# Altium schematic sheet BiSheFinalA.SchDoc(BiSheFinalA)
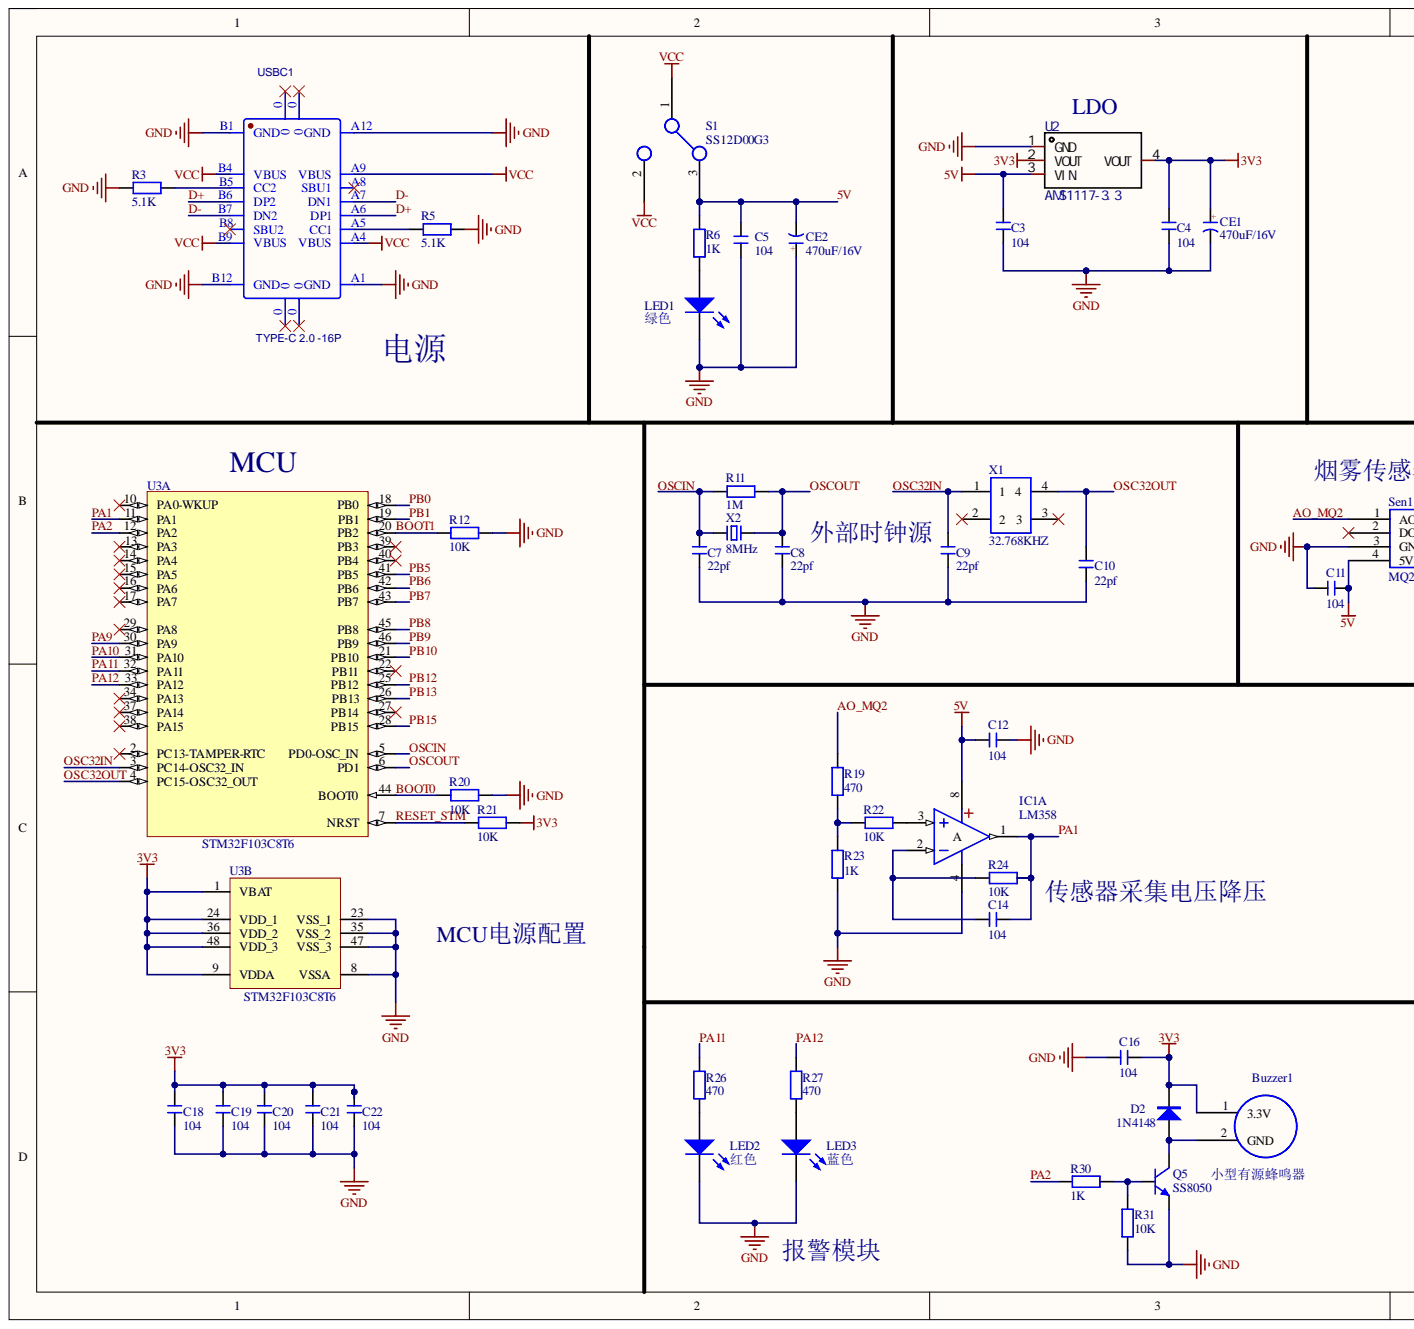
[diagram p0: BiSheFinalA.SchDoc, left]
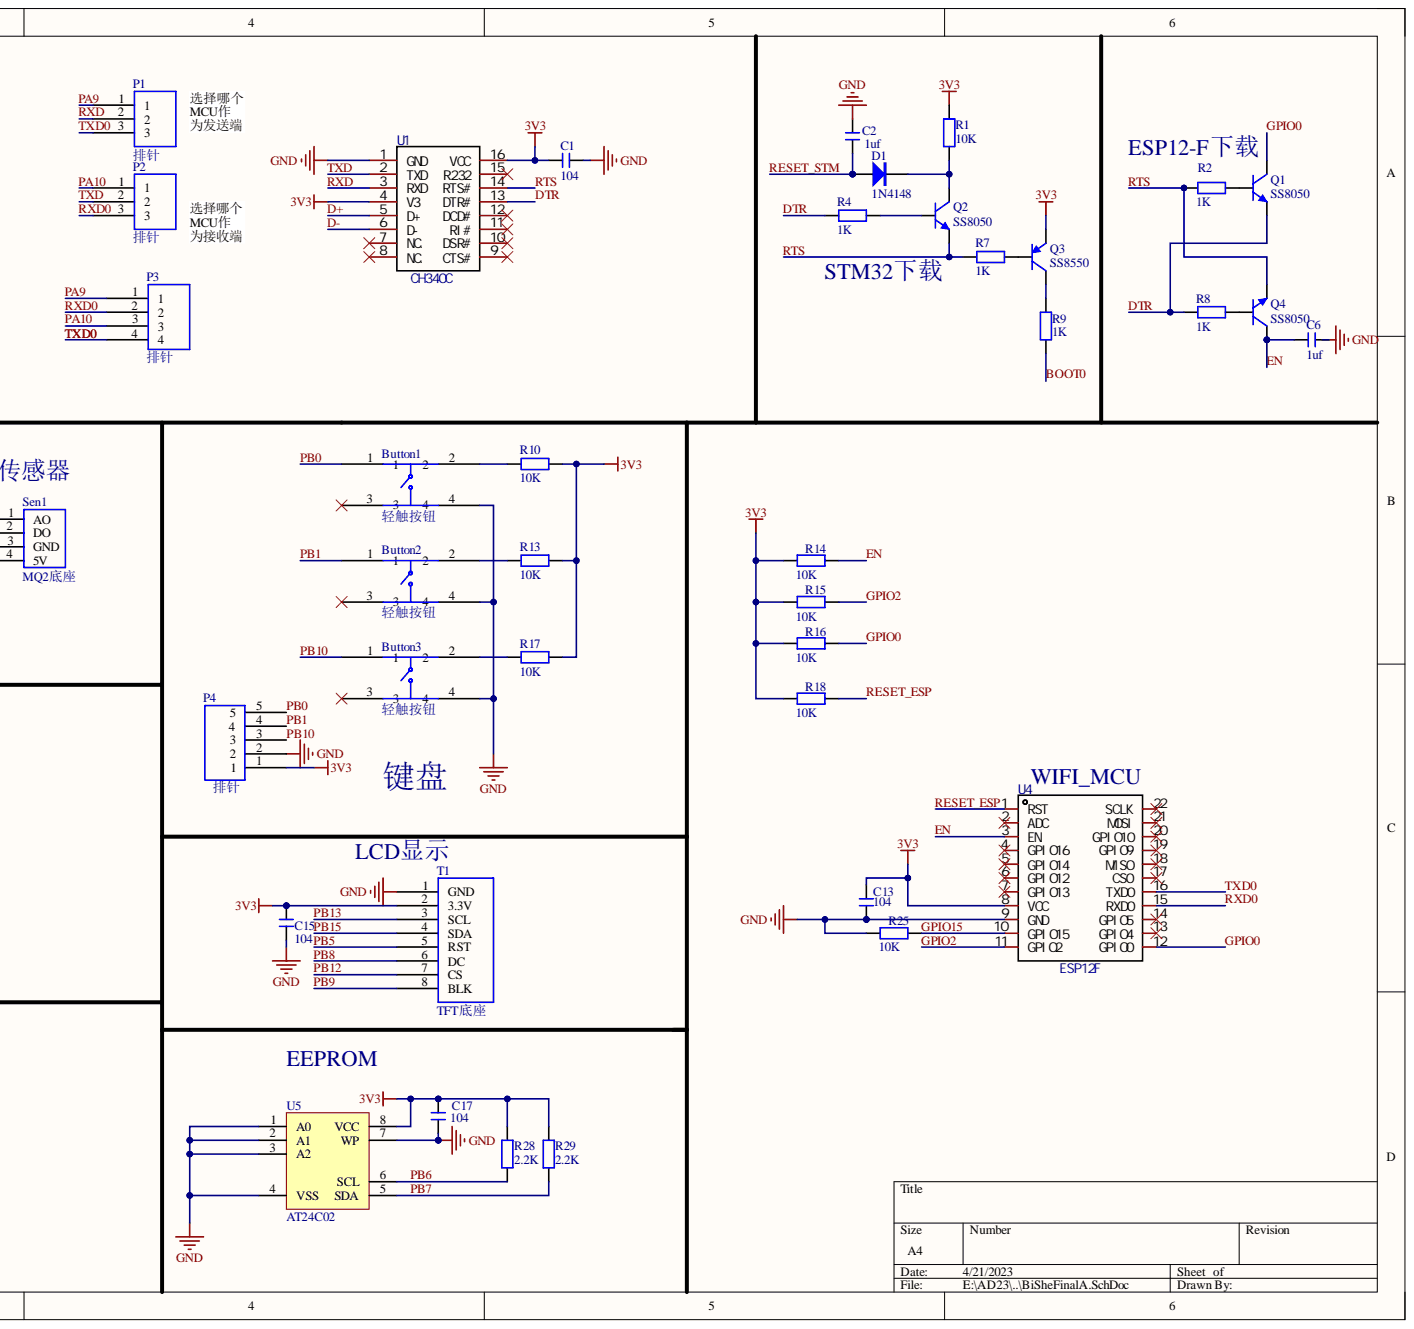
[diagram p1: BiSheFinalA.SchDoc, right]

## Parts
Button1  轻触按钮  [Button]
Button2  轻触按钮  [Button]
Button3  轻触按钮  [Button]
Buzzer1  小型有源蜂鸣器  [Buzzer_Mini]
C1  104  [C 0805_L]
C2  1uf  [C 0805_L]
C3  104  [C 0805_L]
C4  104  [C 0805_L]
C5  104  [C 0805_L]
C6  1uf  [C 0805_L]
C7  22pf  [C 0805_L]
C8  22pf  [C 0805_L]
C9  22pf  [C 0805_L]
C10  22pf  [C 0805_L]
C11  104  [C 0805_L]
C12  104  [C 0805_L]
C13  104  [C 0805_L]
C14  104  [C 0805_L]
C15  104  [C 0805_L]
C16  104  [C 0805_L]
C17  104  [C 0805_L]
C18  104  [C 0805_L]
C19  104  [C 0805_L]
C20  104  [C 0805_L]
C21  104  [C 0805_L]
C22  104  [C 0805_L]
CE1  470uF/16V  [CAP 3.5*8*11.5]
CE2  470uF/16V  [CAP 3.5*8*11.5]
D1  1N4148  [SOD-123]
D2  1N4148  [SOD-123]
IC1  LM358  [SOP8_N]  (part A)
LED1  绿色  [LED 5MM-B]
LED2  红色  [LED 5MM-B]
LED3  蓝色  [LED 5MM-B]
P1  排针  [3Pin]
P2  排针  [3Pin]
P3  排针  [4PIN]
P4  排针  [5pin]
Q1  SS8050  [SOT23-3N]
Q2  SS8050  [SOT23-3N]
Q3  SS8550  [SOT23-3N]
Q4  SS8050  [SOT23-3N]
Q5  SS8050  [SOT23-3N]
R1  10K  [R 0805_L]
R2  1K  [R 0805_L]
R3  5.1K  [R 0805_L]
R4  1K  [R 0805_L]
R5  5.1K  [R 0805_L]
R6  1K  [R 0805_L]
R7  1K  [R 0805_L]
R8  1K  [R 0805_L]
R9  1K  [R 0805_L]
R10  10K  [R 0805_L]
R11  1M  [R 0805_L]
R12  10K  [R 0805_L]
R13  10K  [R 0805_L]
R14  10K  [R 0805_L]
R15  10K  [R 0805_L]
R16  10K  [R 0805_L]
R17  10K  [R 0805_L]
R18  10K  [R 0805_L]
R19  470  [R 0805_L]
R20  10K  [R 0805_L]
R21  10K  [R 0805_L]
R22  10K  [R 0805_L]
R23  1K  [R 0805_L]
R24  10K  [R 0805_L]
R25  10K  [R 0805_L]
R26  470  [R 0805_L]
R27  470  [R 0805_L]
R28  2.2K  [R 0805_L]
R29  2.2K  [R 0805_L]
R30  1K  [R 0805_L]
R31  10K  [R 0805_L]
S1  SS12D00G3  [SS12D00G3]
Sen1  MQ2底座  [MQ2底座]
T1  TFT底座  [TFT底座]
U1  CH340C  [SOP-16_L10.0-W3.9-P1.27-LS6.0-BL]
U2  AMS1117-3.3  [SOT-223-3_L6.5-W3.4-P2.30-LS7.0-BR]
U3  STM32F103C8T6  [LQFP48_N]  (parts A,B)
U4  ESP12F  [WIFIM-SMD_ESP-12F-ESP8266MOD]
U5  AT24C02  [SOIC8_N]
USBC1  TYPE-C 2.0 -16P  [USB-C-SMD_TYPE-C-USB-5]
X1  32.768KHZ  [MC-306]
X2  8MHz  [OSC HC-49S]

## Nets
3V3: Buzzer1.1 C1.1 C4.1 C13.1 C15.2 C16.2 C17.2 C18.1 C19.1 C20.1 C21.1 C22.1 CE1.1 D2.2 P4.1 Q3.2 R1.1 R10.1 R13.1 R14.1 R15.1 R16.1 R17.1 R18.1 R21.1 R28.1 R29.1 T1.2(3.3V) U1.4(V3) U1.16(VCC) U2.2(VOUT) U2.4(VOUT) U3.1(VBAT) U3.9(VDDA) U3.24(VDD_1) U3.36(VDD_2) U3.48(VDD_3) U4.8(VCC) U5.8(VCC)
GND: Button1.4 Button2.4 Button3.4 C1.2 C2.1 C3.2 C4.2 C5.1 C6.2 C7.2 C8.2 C9.2 C10.2 C11.1 C12.1 C13.2 C15.1 C16.1 C17.1 C18.2 C19.2 C20.2 C21.2 C22.2 CE1.2 CE2.1 IC1.4 LED1.2 LED2.2 LED3.2 P4.2 Q5.2 R3.2 R5.1 R12.1 R20.1 R23.2 R25.1 R31.2 Sen1.3(GND) T1.1(GND) U1.1(GND) U2.1(GND) U3.8(VSSA) U3.23(VSS_1) U3.35(VSS_2) U3.47(VSS_3) U4.9(GND) U5.1(A0) U5.2(A1) U5.3(A2) U5.4(VSS) U5.7(WP) USBC1.A1(GND) USBC1.A12(GND) USBC1.B1(GND) USBC1.B12(GND)
VCC: S1.1 S1.2 USBC1.A4(VBUS) USBC1.A9(VBUS) USBC1.B4(VBUS) USBC1.B9(VBUS)
5V: C3.1 C5.2 C11.2 C12.2 CE2.2 IC1.8(A) R6.1 S1.3 Sen1.4(5V) U2.3(VIN)
AO_MQ2: R19.1 Sen1.1(AO)
BOOT0: R9.2 R20.2 U3.44(BOOT0)
BOOT1: R12.2 U3.20(PB2)
D+: U1.5(D+) USBC1.A6(DP1) USBC1.B6(DP2)
D-: U1.6(D-) USBC1.A7(DN1) USBC1.B7(DN2)
DTR: Q1.2 R4.1 R8.2 U1.13(DTR#)
EN: C6.1 Q4.3 R14.2 U4.3(EN)
GPIO0: Q1.3 R16.2 U4.12(GPIO0)
GPIO2: R15.2 U4.11(GPIO2)
GPIO15: R25.2 U4.10(GPIO15)
OSC32IN: C9.1 U3.3(PC14-OSC32_IN) X1.1
OSC32OUT: C10.1 U3.4(PC15-OSC32_OUT) X1.4
OSCIN: C7.1 R11.2 U3.5(PD0-OSC_IN) X2.1
OSCOUT: C8.1 R11.1 U3.6(PD1) X2.2
PA1: C14.1 IC1.1 R24.2 U3.11(PA1)
PA2: R30.1 U3.12(PA2)
PA9: P1.1 P3.1 U3.30(PA9)
PA10: P2.1 P3.3 U3.31(PA10)
PA11: R26.1 U3.32(PA11)
PA12: R27.1 U3.33(PA12)
PB0: Button1.1 P4.5 U3.18(PB0)
PB1: Button2.1 P4.4 U3.19(PB1)
PB5: T1.5(RST) U3.41(PB5)
PB6: R28.2 U3.42(PB6) U5.6(SCL)
PB7: R29.2 U3.43(PB7) U5.5(SDA)
PB8: T1.6(DC) U3.45(PB8)
PB9: T1.8(BLK) U3.46(PB9)
PB10: Button3.1 P4.3 U3.21(PB10)
PB12: T1.7(CS) U3.25(PB12)
PB13: T1.3(SCL) U3.26(PB13)
PB15: T1.4(SDA) U3.28(PB15)
RESET_ESP: R18.2 U4.1(RST)
RESET_STM: C2.2 D1.1 R21.2 U3.7(NRST)
RTS: Q2.2 Q4.2 R2.2 R7.1 U1.14(RTS#)
RXD: P1.2 U1.3(RXD)
RXD0: P2.3 P3.2 U4.15(RXD0)
TXD: P2.2 U1.2(TXD)
TXD0: P1.3 P3.4 U4.16(TXD0)
NetButton1_2: Button1.2 R10.2
NetButton2_2: Button2.2 R13.2
NetButton3_2: Button3.2 R17.2
NetBuzzer1_2: Buzzer1.2 D2.1 Q5.3
NetC14_2: C14.2 IC1.2 R24.1
NetD1_2: D1.2 Q2.3 R1.2
NetIC1_3: IC1.3 R22.1
NetLED1_1: LED1.1 R6.2
NetLED2_1: LED2.1 R26.2
NetLED3_1: LED3.1 R27.2
NetQ1_1: Q1.1 R2.1
NetQ2_1: Q2.1 R4.2
NetQ3_1: Q3.1 R7.2
NetQ3_3: Q3.3 R9.1
NetQ4_1: Q4.1 R8.1
NetQ5_1: Q5.1 R30.2 R31.1
NetR3_1: R3.1 USBC1.B5(CC2)
NetR5_2: R5.2 USBC1.A5(CC1)
NetR19_2: R19.2 R22.2 R23.1

Not connected: Button1 3; Button2 3; Button3 3; Sen1 2(DO); U1 7(NC.) 8(NC.) 9(CTS#) 10(DSR#) 11(RI#) 12(DCD#) 15(R232); U3 2(PC13-TAMPER-RTC) 10(PA0-WKUP) 13(PA3) 14(PA4) 15(PA5) 16(PA6) 17(PA7) 22(PB11) 27(PB14) 29(PA8) 34(PA13) 37(PA14) 38(PA15) 39(PB3) 40(PB4); U4 2(ADC) 4(GPIO16) 5(GPIO14) 6(GPIO12) 7(GPIO13) 13(GPIO4) 14(GPIO5) 17(CS0) 18(MISO) 19(GPIO9) 20(GPIO10) 21(MOSI) 22(SCLK); USBC1 0 A8(SBU1) B8(SBU2); X1 2 3

## Library data of the parts
Button1-Button3 = Button: 6*6*7轻触按钮; Component Kind: Standard; Library Name: BiShe.SchLib
Buzzer1 = Buzzer: 蜂鸣器; Component Kind: Standard; Library Name: BiSheFinal.SCHLIB
C1-C22 = C: 贴片电容; BOM名称: 无极性贴片电容; 淘宝ID: 封装库来自淘宝 ID：黄晶宝石; Component Kind: Standard; Library Name: 0.1 - 电阻-电容-电感.SchLib; 唯一出售店铺网址: https://shop463601444.taobao.com; 联系售后，技术支持: https://shop463601444.taobao.com
CE1 CE2 = CE: 直插电解电容; 淘宝ID: 封装库来自淘宝 ID：黄晶宝石; BOM名称: 直插电解电容; Component Kind: Standard; Library Name: 0.1 - 电阻-电容-电感.SchLib; 联系售后: https://item.taobao.com/item.htm?id=566775463719
D1 D2 = 1N4148: 开关二极管; BOM名称: 高速开关二极管; 淘宝ID: 封装库来自淘宝 ID：黄晶宝石; Component Kind: Standard; Library Name: 0.2 - 二极管-整流桥.SchLib
IC1 = LM358: 双路运放; 学习资源: 最新版本发布https://item.taobao.com/item.htm?id=566775463719; BOM名称: 双路运放; Component Kind: Standard; Library Name: 1.0 - 常见芯片.SchLib
LED1-LED3 = LED-5MM: 直插5mm LED; BOM名称: 5mm插件LED; 版权归属: 封装库来自淘宝 ID：黄晶宝石; Component Kind: Standard; Library Name: 0.3 - LED发光二极管.SchLib; 学习资源: https://item.taobao.com/item.htm?id=566775463719
P1 P2 = 3Pin: 3p排针; Component Kind: Standard; Library Name: BiSheFinal.SCHLIB
P3 = 4Pin: 4p排针; Component Kind: Standard; Library Name: BiSheFinal.SCHLIB
P4 = 5Pin: 5Pin排针; Component Kind: Standard; Library Name: BiSheFinal.SCHLIB
Q1 Q2 Q4 Q5 = 8050-SMD: 高频放大-NPN型; 属性: 40V 1.5A 1W 100MHZ; 版权归属: 封装库来自淘宝 ID：黄晶宝石; 联系售后: 最新版本发布https://item.taobao.com/item.htm?id=566775463719; BOM名称: 高频放大-NPN型; Component Kind: Standard; Library Name: 0.4 - 三极管.SchLib
Q3 = 8550-SMD: 高频放大-PNP型; 学习资源: 最新版本发布https://item.taobao.com/item.htm?id=566775463719; 属性: 40V 1.5A 1W 100MHZ; 版权归属: 封装库来自淘宝 ID：黄晶宝石; BOM名称: 高频放大-PNP型; Component Kind: Standard; Library Name: 0.4 - 三极管.SchLib
R1-R31 = R: 贴片电阻; 封装库来自淘宝卖家 ID：黄晶宝石: 其他店铺购入均为盗版，不享受售后技术支持服务，请立即申请退款; 版权归属: 来自淘宝 ID：黄晶宝石; BOM名称: 贴片电阻; Component Kind: Standard; Library Name: 0.1 - 电阻-电容-电感.SchLib; 联系售后: https://item.taobao.com/item.htm?id=566775463719
S1 = SS12D00G3: 拨动开关; Component Kind: Standard; Library Name: BiSheFinal.SCHLIB
Sen1 = MQ2底座: 气体传感器; Component Kind: Standard; Library Name: BiSheFinal.SCHLIB
T1 = TFT底座: 底座; Component Kind: Standard; Library Name: BiSheFinal.SCHLIB
U1 = CH340C: 驱动器/接收器数:-;协议类别:USB 2.0;类型:Transceiver;数据速率:2Mbps;; Manufacturer: WCH(南京沁恒); Add into BOM: yes; Data Rate: 2Mbps; Protocol: USB 2.0; Supply Voltage: 3.3V, 5V; CH340C: CH340C; Component Kind: Standard; Convert to PCB: yes; Datasheet: https://atta.szlcsc.com/upload/public/pdf/source/20200819/C84681_ADBED554CE321879DBD6B1D06488689C.pdf; Device: CH340C; JLCPCB Part Class: Extended Part; LCSC Part Name: CH340C; Manufacturer Part: CH340C; Number of Drivers/Receivers: -; Supplier: LCSC; Supplier Footprint: SOP-16_150mil; Supplier Part: C84681; Symbol: CH340C; Type: Transceiver; Library Name: BiSheFinal.SCHLIB
U2 = AMS1117-3.3_C6186: 输出类型:固定;输出极性:正;最大输入电压:-;输出电压:3.3V;输出电流:1A;电源纹波抑制比(PSRR):72dB@(120Hz);; Manufacturer: AMS; Add into BOM: yes; Dropout Voltage: 1.3V@(800mA); Number of Outputs: 1; Output Current: 1A; Output Polarity: 正; Output Type: 固定; Output Voltage: 3.3V; AMS1117: AMS1117-3.3; Component Kind: Standard; Convert to PCB: yes; Datasheet: https://atta.szlcsc.com/upload/public/pdf/source/20180727/C6186_7A095716F0823C02F1997A905E26C086.pdf; Device: AMS1117-3.3_C6186; Feature: -; JLCPCB Part Class: Basic Part; LCSC Part Name: 正 Vout=3.3V 1A 72dB@(120Hz); Manufacturer Part: AMS1117-3.3; Maximum Input Voltage: -; Noise: -; Operating Temperature: -; Power Supply Rejection Ratio (PSRR): 72dB@(120Hz); Quiescent Current (Ground Current): -; Supplier: LCSC; Supplier Footprint: SOT-223; Supplier Part: C6186; Symbol: AMS1117-3.3; Library Name: BiSheFinal.SCHLIB
U3 = STM32F103C8T6: STM32 ARM-based 32-bit MCU Performance Line with 64 kB Flash, 20 kB Internal RAM, -40 to +85癈 Temperature, 48-Pin LQFP, Tray; Component Kind: Standard; ComponentLink1Description: Manufacturer URL; ComponentLink1URL: http://www.st.com/; ComponentLink2Description: STM32F103 Datasheet; ComponentLink2URL: http://www.st.com/internet/com/TECHNICAL_RESOURCES/TECHNICAL_LITERATURE/DATASHEET/CD00161566.pdf; ComponentLink3Description: STM32 Family Web Page; ComponentLink3URL: www.st.com/stm32; DatasheetVersion: rev13.0, Apr-2011; Library Name: 2.3 - STM32 F1系列单片机.SchLib; PackageDescription: 48-Pin Low-Profile Quad Flat Pack, 7 x 7 mm Body, 0.5 mm Pitch (LQFP48); PackageReference: LQFP48; PackageVersion: rev13, Apr-2011; 联系售后: https://shop463601444.taobao.com
U4 = ESP-12F(ESP8266MOD): 频率:2.4GHz;发射功率:16dBm;核心处理器:ESP8266;天线形式:PCB 板载天线;接收灵敏度:-90dBm;; Manufacturer: Ai-Thinker(安信可); Add into BOM: yes; Operating Voltage: 3.3V; Output Power: 16dBm; Receive  Current: 120mA; Send  Current: 170mA; Sensitivity: -90dBm; Support Interface: GPIO；UART；I2C；PWM；SPI；ADC; Value: 2.4GHz; Wireless Standard: 802.11b/g/n; Antenna Type: PCB 板载天线; Component Kind: Standard; Convert to PCB: yes; Core Processor: ESP8266; Datasheet: https://atta.szlcsc.com/upload/public/pdf/source/20210219/C82891_ABD84A460F4F056A2757267CCCA4B508.pdf; Device: ESP-12F(ESP8266MOD); ESP: ESP12F; JLCPCB Part Class: Extended Part; LCSC Part Name: ESP-12F(ESP8266MOD); Manufacturer Part: ESP-12F(ESP8266MOD); Operating Temperature: -40℃~+85℃; PCB3D: WIFIM-SMD_WIFI-L24.0-W16.0-H3.1; Supplier: LCSC; Supplier Footprint: SMD,16x24mm; Supplier Part: C82891; Symbol: ESP-12F(ESP8266MOD); Library Name: BiSheFinal.SCHLIB
U5 = AT24C02: EEROM存储器; Component Kind: Standard; Library Name: 1.0 - 常见芯片.SchLib
USBC1 = USB2.0 Type-C 16Pin母座: USB2.0 Type-C 母座 16Pin; BOM_Manufacturer Part: -; BOM_Supplier: LCSC; Component Kind: Standard; spiceSymbolName: TYPE-C 3.1 -16P_C168689; Library Name: BiSheFinal.SCHLIB
X1 = MC-306: 贴片32.768KHZ; Component Kind: Standard; Library Name: BiSheFinal.SCHLIB
X2 = XTAL-2P: 2脚无源脚晶振; 版权归属: 封装库来自淘宝卖家 ID：黄晶宝石; BOM名称: 2脚无源脚晶振; Component Kind: Standard; Library Name: 2.0 - 保险丝-晶振-光耦.SchLib; 学习资源: https://shop463601444.taobao.com
